# Altium schematic sheet 14audio.SchDoc
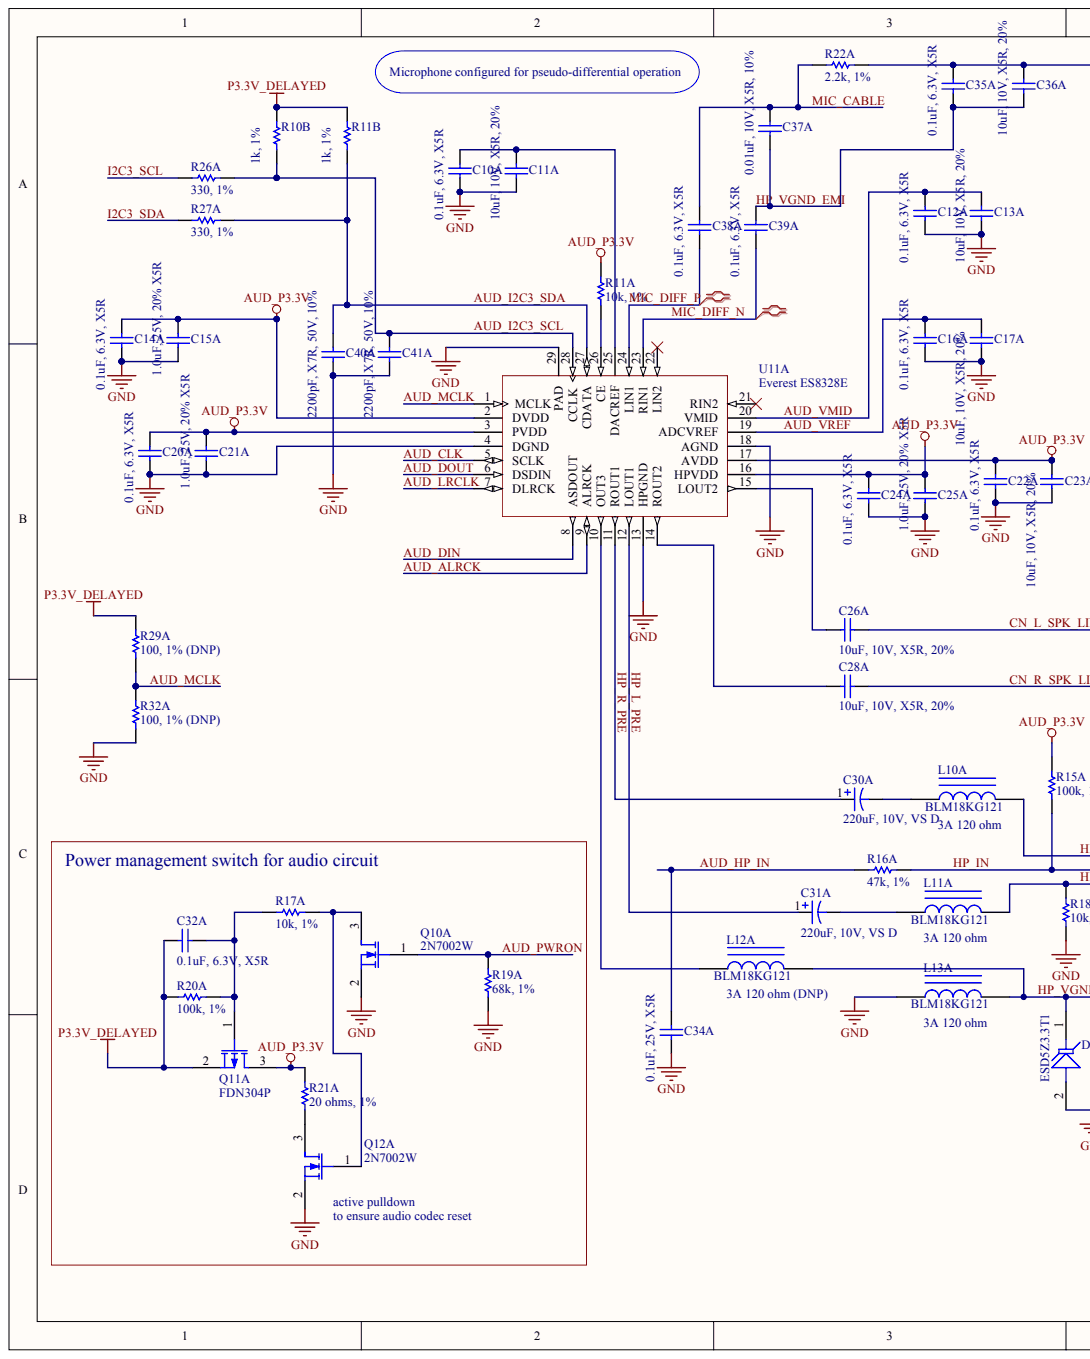
[diagram p0: 14audio.SchDoc, left]
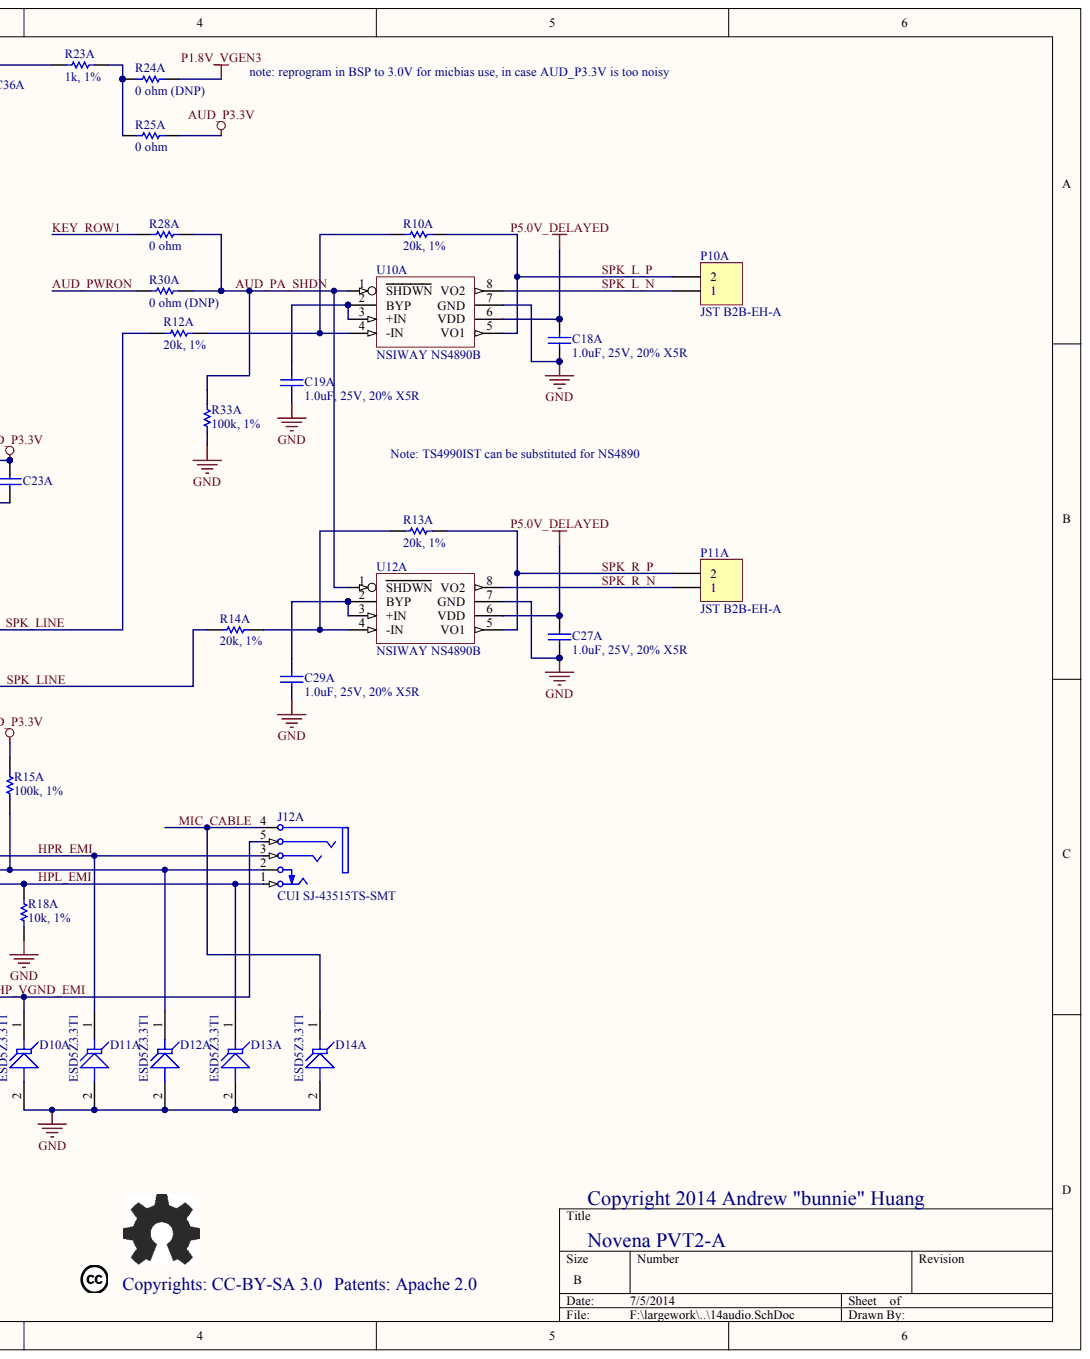
[diagram p1: 14audio.SchDoc, right]

## Parts
C10A  0.1uF, 6.3V, X5R
C11A  10uF, 10V, X5R, 20%
C12A  0.1uF, 6.3V, X5R
C13A  10uF, 10V, X5R, 20%
C14A  0.1uF, 6.3V, X5R
C15A  1.0uF, 25V, 20% X5R
C16A  0.1uF, 6.3V, X5R
C17A  10uF, 10V, X5R, 20%
C18A  1.0uF, 25V, 20% X5R
C19A  1.0uF, 25V, 20% X5R
C20A  0.1uF, 6.3V, X5R
C21A  1.0uF, 25V, 20% X5R
C22A  0.1uF, 6.3V, X5R
C23A  10uF, 10V, X5R, 20%
C24A  0.1uF, 6.3V, X5R
C25A  1.0uF, 25V, 20% X5R
C26A  10uF, 10V, X5R, 20%
C27A  1.0uF, 25V, 20% X5R
C28A  10uF, 10V, X5R, 20%
C29A  1.0uF, 25V, 20% X5R
C30A  220uF, 10V, VS D
C31A  220uF, 10V, VS D
C32A  0.1uF, 6.3V, X5R
C34A  0.1uF, 25V, X5R
C35A  0.1uF, 6.3V, X5R
C36A  10uF, 10V, X5R, 20%
C37A  0.01uF, 10V, X5R, 10%
C38A  0.1uF, 6.3V, X5R
C39A  0.1uF, 6.3V, X5R
C40A  2200pF, X7R, 50V, 10%
C41A  2200pF, X7R, 50V, 10%
D10A  ESD5Z3.3T1
D11A  ESD5Z3.3T1
D12A  ESD5Z3.3T1
D13A  ESD5Z3.3T1
D14A  ESD5Z3.3T1
J12A  CUI SJ-43515TS-SMT
L10A  BLM18KG121  value 3A 120 ohm
L11A  BLM18KG121  value 3A 120 ohm
L12A  BLM18KG121  value 3A 120 ohm (DNP)
L13A  BLM18KG121  value 3A 120 ohm
P10A  JST B2B-EH-A
P11A  JST B2B-EH-A
Q10A  2N7002W
Q11A  FDN304P
Q12A  2N7002W
R10A  20k, 1%
R10B  1k, 1%
R11A  10k, 1%
R11B  1k, 1%
R12A  20k, 1%
R13A  20k, 1%
R14A  20k, 1%
R15A  100k, 1%
R16A  47k, 1%
R17A  10k, 1%
R18A  10k, 1%
R19A  68k, 1%
R20A  100k, 1%
R21A  20 ohms, 1%
R22A  2.2k, 1%
R23A  1k, 1%
R24A  0 ohm (DNP)
R25A  0 ohm
R26A  330, 1%
R27A  330, 1%
R28A  0 ohm
R29A  100, 1% (DNP)
R30A  0 ohm (DNP)
R32A  100, 1% (DNP)
R33A  100k, 1%
U10A  NSIWAY NS4890B
U11A  Everest ES8328E
U12A  NSIWAY NS4890B

## Nets
AUD_P3.3V: C14A.2 C15A.2 C20A.2 C21A.2 C22A.2 C23A.1 C24A.2 C25A.2 Q11A.3 R11A.2 R15A.2 R21A.1 R25A.2 U11A.2(DVDD) U11A.3(PVDD) U11A.16(HPVDD) U11A.17(AVDD)
GND: C10A.1 C11A.2 C12A.1 C13A.2 C14A.1 C15A.1 C16A.1 C17A.2 C18A.1 C19A.1 C20A.1 C21A.1 C22A.1 C23A.2 C24A.1 C25A.1 C27A.1 C29A.1 C34A.1 C40A.1 C41A.1 D10A.2 D11A.2 D12A.2 D13A.2 D14A.2 L13A.1 Q10A.2 Q12A.2 R18A.2 R19A.2 R32A.1 R33A.1 U10A.7(GND) U11A.4(DGND) U11A.13(HPGND) U11A.18(AGND) U11A.29(PAD) U12A.7(GND)
P1.8V_VGEN3: R24A.2
P3.3V_DELAYED: C32A.2 Q11A.2 R10B.2 R11B.2 R20A.2 R29A.2
P5.0V_DELAYED: C18A.2 C27A.2 U10A.6(VDD) U12A.6(VDD)
AUD_ALRCK: U11A.9(ALRCK)
AUD_CLK: U11A.5(SCLK)
AUD_DIN: U11A.8(ASDOUT)
AUD_DOUT: U11A.6(DSDIN)
AUD_HP_IN: C34A.2 R16A.2
AUD_I2C3_SCL: C41A.2 R10B.1 R26A.1 U11A.28(CCLK)
AUD_I2C3_SDA: C40A.2 R11B.1 R27A.1 U11A.27(CDATA)
AUD_LRCLK: U11A.7(DLRCK)
AUD_MCLK: R29A.1 R32A.2 U11A.1(MCLK)
AUD_PA_SHDN: R28A.2 R30A.2 R33A.2 U10A.1(SHDWN) U12A.1(SHDWN)
AUD_PWRON: Q10A.1 R19A.1 R30A.1
AUD_VMID: C12A.2 C13A.1 U11A.20(VMID)
AUD_VREF: C16A.2 C17A.1 U11A.19(ADCVREF)
CN_L_SPK_LINE: C26A.2 R12A.2
CN_R_SPK_LINE: C28A.2 R14A.2
HP_IN: D12A.1 J12A.2 R15A.1 R16A.1
HP_L_PRE: C31A.1 U11A.12(LOUT1)
HP_R_PRE: C30A.1 U11A.11(ROUT1)
HP_VGND_EMI: C35A.1 C36A.2 C37A.2 C39A.2 D10A.1 J12A.5 L12A.2 L13A.2
HPL_EMI: D13A.1 J12A.1 L11A.2 R18A.1
HPR_EMI: D11A.1 J12A.3 L10A.2
I2C3_SCL: R26A.2
I2C3_SDA: R27A.2
KEY_ROW1: R28A.1
MIC_CABLE: C37A.1 C38A.2 D14A.1 J12A.4 R22A.1
MIC_DIFF_N: C39A.1 U11A.23(RIN1)
MIC_DIFF_P: C38A.1 U11A.24(LIN1)
SPK_L_N: P10A.1 U10A.8(VO2)
SPK_L_P: P10A.2 R10A.1 U10A.5(VO1)
SPK_R_N: P11A.1 U12A.8(VO2)
SPK_R_P: P11A.2 R13A.1 U12A.5(VO1)
NetC10A_2: C10A.2 C11A.1 U11A.25(DACREF)
NetC19A_2: C19A.2 U10A.2(BYP) U10A.3(+IN)
NetC26A_1: C26A.1 U11A.15(LOUT2)
NetC28A_1: C28A.1 U11A.14(ROUT2)
NetC29A_2: C29A.2 U12A.2(BYP) U12A.3(+IN)
NetC30A_2: C30A.2 L10A.1
NetC31A_2: C31A.2 L11A.1
NetC32A_1: C32A.1 Q11A.1 R17A.1 R20A.1
NetC35A_2: C35A.2 C36A.1 R22A.2 R23A.1
NetL12A_1: L12A.1 U11A.10(OUT3)
NetQ10A_3: Q10A.3 Q12A.1 R17A.2
NetQ12A_3: Q12A.3 R21A.2
NetR10A_2: R10A.2 R12A.1 U10A.4(-IN)
NetR11A_1: R11A.1 U11A.26(CE)
NetR13A_2: R13A.2 R14A.1 U12A.4(-IN)
NetR23A_2: R23A.2 R24A.1 R25A.1

Not connected: U11A 21(RIN2) 22(LIN2)

## Library data of the parts
J12A = (no symbol name): PackageDocument: 2003; PCB_Layout: Complies with manufacturer's recommendation.; DrillAndSlotSize: Corresponds to nominal value.; Published: 15-Jul-2004; DatasheetDocument: 2003; PackageReference: S1VS_SSB; ComponentLink1Description: Manufacturer Link; ComponentLink1URL: http://www.cliffuk.co.uk/; Publisher: Altium Limited
R10A R10B R11A R11B R12A R13A R14A R16A R17A R18A R19A R21A R22A R23A R24A R25A R26A R27A R28A R29A R30A R32A = (no symbol name): Value: 100k; Published: 8-Jun-2000; LatestRevisionDate: 17-Jul-2002; LatestRevisionNote: Re-released for DXP Platform.; PackageReference: AXIAL-0.3; Publisher: Altium Limited
C10A C11A C12A C13A C14A C15A C16A C17A C18A C19A C20A C21A C22A C23A C24A C25A C26A C27A C28A C29A C30A C31A C32A C34A C35A C36A C37A C38A C39A C40A C41A D10A D11A D12A D13A D14A L10A L11A L12A L13A P10A P11A Q10A Q11A Q12A R15A R20A R33A U10A U11A U12A = (no symbol name)
  C10A C11A C12A C13A C14A C15A C16A C17A C18A C19A C20A C21A C22A C23A C24A C25A C26A C27A C28A C29A C32A C34A C35A C36A C37A C38A C39A C40A C41A: Published: 8-Jun-2000; LatestRevisionDate: 17-Jul-2002; LatestRevisionNote: Re-released for DXP Platform.; PackageReference: C1206
  C30A C31A: Published: 8-Jun-2000; LatestRevisionDate: 17-Jul-2002; LatestRevisionNote: Re-released for DXP Platform.; PackageReference: C0805
  D10A D11A D12A D13A D14A: Published: 24-Mar-1999; LatestRevisionDate: 17-Jul-2002; LatestRevisionNote: Re-released for DXP Platform.; PackageReference: DO-7
  L10A L11A L12A L13A: Published: 24-Mar-1999; LatestRevisionDate: 17-Jul-2002; LatestRevisionNote: Re-released for DXP Platform.; PackageReference: AXIAL-0.9
  P10A P11A: LatestRevisionDate: 17-Jul-2002; LatestRevisionNote: Re-released for DXP Platform.
  Q10A Q12A: Published: 8-Jun-2000; LatestRevisionDate: 17-Jul-2002; LatestRevisionNote: Re-released for DXP Platform.; PackageVersion: 1995/1996; PackageReference: E3
  Q11A: Published: 8-Jun-2000; LatestRevisionDate: 17-Jul-2002; LatestRevisionNote: Re-released for DXP Platform.; PackageVersion: 1995/1996; PackageReference: E3; PackageDescription: TO, Flat Index; 3 In-Line, Axial Leads; Body Dia. 4.6mm; Lead Dia. 0.5 mm (max)
  R15A R20A R33A: Published: 8-Jun-2000; LatestRevisionDate: 17-Jul-2002; LatestRevisionNote: Re-released for DXP Platform.; PackageReference: AXIAL-0.3; Value: 100k
  C10A C11A C12A C13A C14A C15A C16A C17A C18A C19A C20A C21A C22A C23A C24A C25A C26A C27A C28A C29A C30A C31A C32A C34A C35A C36A C37A C38A C39A C40A C41A D10A D11A D12A D13A D14A L10A L11A L12A L13A P10A P11A Q10A Q11A Q12A R15A R20A R33A: Publisher: Altium Limited
